# Altium schematic sheet STM32_CORE.SchDoc(STM32_CORE)
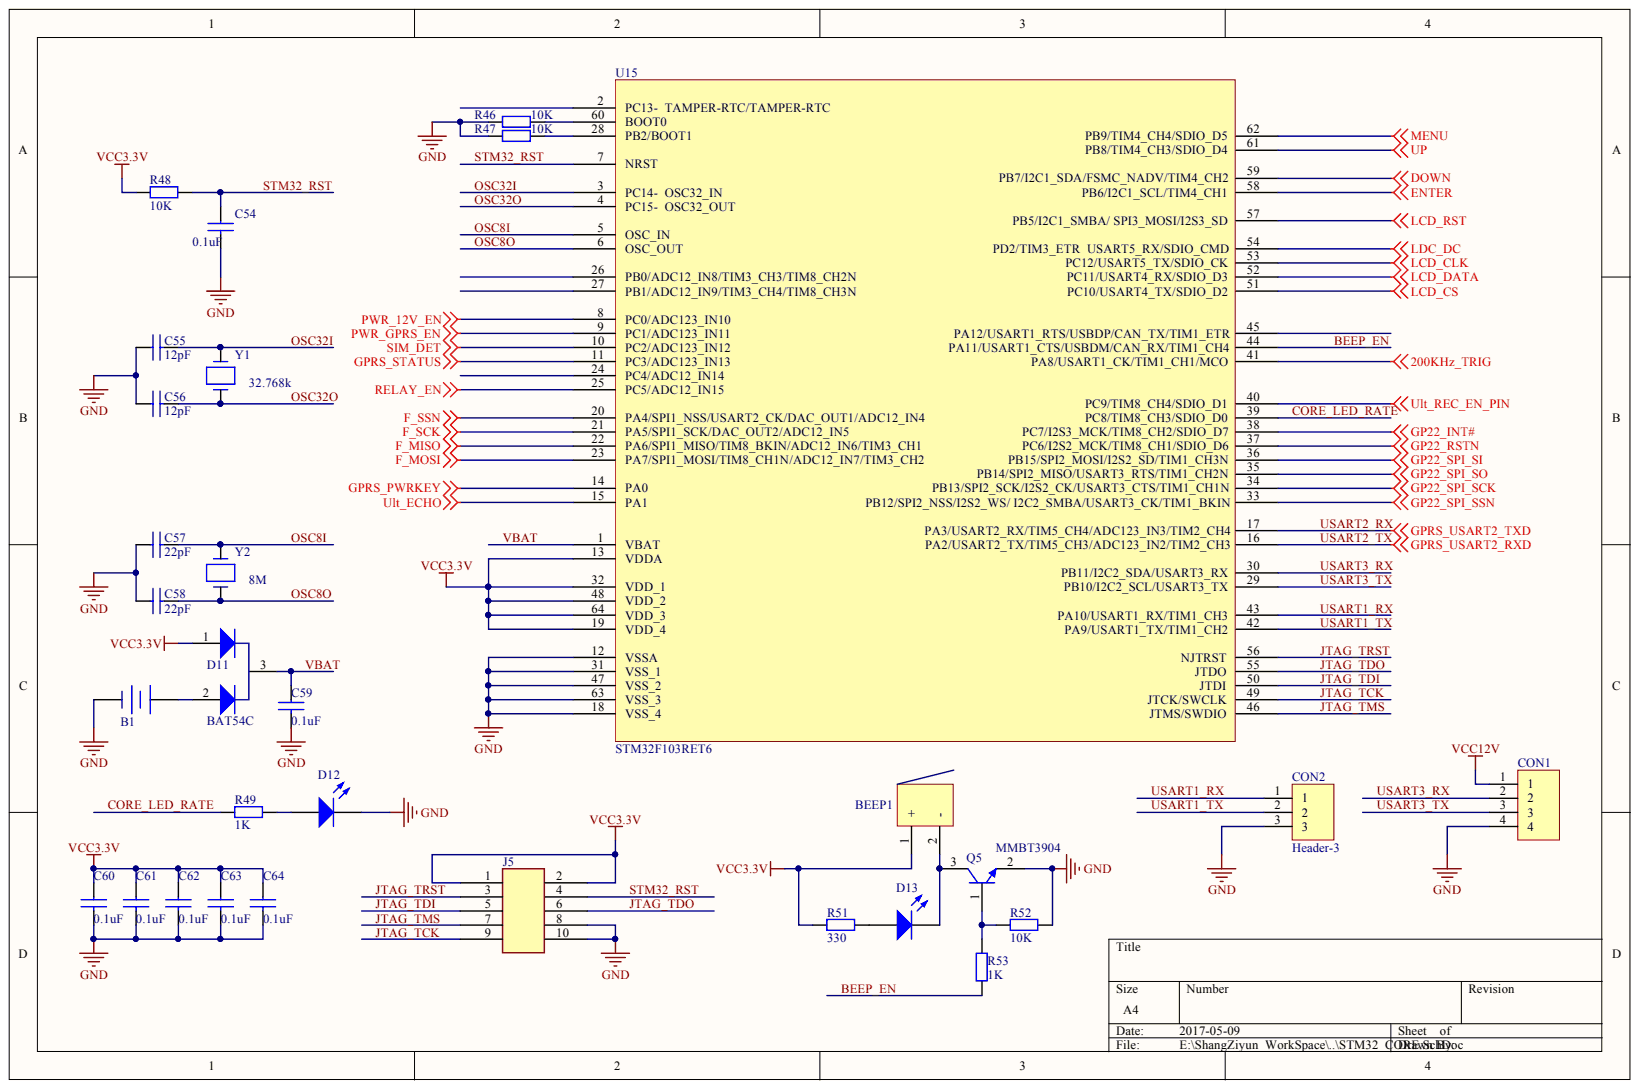
[diagram p0: STM32_CORE.SchDoc]

## Parts
B1  3VCR1202纽扣电池座  [CR1220]  (value not drawn)
BEEP1  Beep  [beep]  (value not drawn)
C54  value 0.1uF  [0603C]  (hidden comment: CAP NP)
C55  value 12pF  [0603C]  (hidden comment: CAP NP)
C56  value 12pF  [0603C]  (hidden comment: CAP NP)
C57  value 22pF  [0603C]  (hidden comment: CAP NP)
C58  value 22pF  [0603C]  (hidden comment: CAP NP)
C59  value 0.1uF  [0603C]  (hidden comment: CAP NP)
C60  value 0.1uF  [0603C]  (hidden comment: CAP NP)
C61  value 0.1uF  [0603C]  (hidden comment: CAP NP)
C62  value 0.1uF  [0603C]  (hidden comment: CAP NP)
C63  value 0.1uF  [0603C]  (hidden comment: CAP NP)
C64  value 0.1uF  [0603C]  (hidden comment: CAP NP)
CON1  CONNECTOR_4PIN  [XH2.54-4]  (value not drawn)
CON2  Header3  value Header-3  [HEADER_3P]
D11  BAT54C  [SOT23]
D12  LED0  [LED_3mm]  (value not drawn)
D13  LED0  [LED_3mm]  (value not drawn)
J5  CON10A  [DC3-10P-2.0]  (value not drawn)
Q5  MMBT3904  [SOT23]
R46  value 10K  [L0603]  (hidden comment: Resistor)
R47  value 10K  [L0603]  (hidden comment: Resistor)
R48  value 10K  [L0603]  (hidden comment: Resistor)
R49  value 1K  [L0603]  (hidden comment: Resistor)
R51  value 330  [L0603]  (hidden comment: Resistor)
R52  value 10K  [L0603]  (hidden comment: Resistor)
R53  value 1K  [L0603]  (hidden comment: Resistor)
U15  STM32F103RET6  [LQFP64]
Y1  value 32.768k  [fc135]  (hidden comment: CRYSTAL_0)
Y2  value 8M  [ABM7]  (hidden comment: CRYSTAL_0)

## Nets
200KHZ_TRIG: U15.41(PA8/USART1_CK/TIM1_CH1/MCO)
DOWN: U15.59(PB7/I2C1_SDA/FSMC_NADV/TIM4_CH2)
ENTER: U15.58(PB6/I2C1_SCL/TIM4_CH1)
F_MISO: U15.22(PA6/SPI1_MISO/TIM8_BKIN/ADC12_IN6/TIM3_CH1)
F_MOSI: U15.23(PA7/SPI1_MOSI/TIM8_CH1N/ADC12_IN7/TIM3_CH2)
F_SCK: U15.21(PA5/SPI1_SCK/DAC_OUT2/ADC12_IN5)
F_SSN: U15.20(PA4/SPI1_NSS/USART2_CK/DAC_OUT1/ADC12_IN4)
GND: B1.2 C54.2 C55.1 C56.1 C57.1 C58.1 C59.2 C60.2 C61.2 C62.2 C63.2 C64.2 CON1.4 CON2.3 D12.2 J5.8 J5.10 Q5.2 R46.1 R47.1 R52.2 U15.12(VSSA) U15.18(VSS_4) U15.31(VSS_1) U15.47(VSS_2) U15.63(VSS_3)
GP22_INT#: U15.38(PC7/I2S3_MCK/TIM8_CH2/SDIO_D7)
GP22_RSTN: U15.37(PC6/I2S2_MCK/TIM8_CH1/SDIO_D6)
GP22_SPI_SCK: U15.34(PB13/SPI2_SCK/I2S2_CK/USART3_CTS/TIM1_CH1N)
GP22_SPI_SI: U15.36(PB15/SPI2_MOSI/I2S2_SD/TIM1_CH3N)
GP22_SPI_SO: U15.35(PB14/SPI2_MISO/USART3_RTS/TIM1_CH2N)
GP22_SPI_SSN: U15.33(PB12/SPI2_NSS/I2S2_WS/_I2C2_SMBA/USART3_CK/TIM1_BKIN)
GPRS_PWRKEY: U15.14(PA0)
GPRS_STATUS: U15.11(PC3/ADC123_IN13)
LCD_CLK: U15.53(PC12/USART5_TX/SDIO_CK)
LCD_CS: U15.51(PC10/USART4_TX/SDIO_D2)
LCD_DATA: U15.52(PC11/USART4_RX/SDIO_D3)
LCD_RST: U15.57(PB5/I2C1_SMBA/_SPI3_MOSI/I2S3_SD)
LDC_DC: U15.54(USART5_RX/SDIO_CMD)
MENU: U15.62(PB9/TIM4_CH4/SDIO_D5)
PWR_12V_EN: U15.8(PC0/ADC123_IN10)
PWR_GPRS_EN: U15.9(PC1/ADC123_IN11)
RELAY_EN: U15.25(PC5/ADC12_IN15)
SIM_DET: U15.10(PC2/ADC123_IN12)
ULT_ECHO: U15.15(PA1)
ULT_REC_EN_PIN: U15.40(PC9/TIM8_CH4/SDIO_D1)
UP: U15.61(PB8/TIM4_CH3/SDIO_D4)
VCC3.3V: BEEP1.1 C60.1 C61.1 C62.1 C63.1 C64.1 D11.1 J5.1 J5.2 R48.1 R51.2 U15.13(VDDA) U15.19(VDD_4) U15.32(VDD_1) U15.48(VDD_2) U15.64(VDD_3)
VCC12V: CON1.1
BEEP_EN: R53.1 U15.44(PA11/USART1_CTS/USBDM/CAN_RX/TIM1_CH4)
CORE_LED_RATE: R49.2 U15.39(PC8/TIM8_CH3/SDIO_D0)
JTAG_TCK: J5.9 U15.49(JTCK/SWCLK)
JTAG_TDI: J5.5 U15.50(JTDI)
JTAG_TDO: J5.6 U15.55(JTDO)
JTAG_TMS: J5.7 U15.46(JTMS/SWDIO)
JTAG_TRST: J5.3 U15.56(NJTRST)
OSC8I: C57.2 U15.5(OSC_IN) Y2.1
OSC8O: C58.2 U15.6(OSC_OUT) Y2.2
OSC32I: C55.2 U15.3(PC14-) Y1.1
OSC32O: C56.2 U15.4(PC15-) Y1.2
STM32_RST: C54.1 J5.4 R48.2 U15.7(NRST)
USART1_RX: CON2.1 U15.43(PA10/USART1_RX/TIM1_CH3)
USART1_TX: CON2.2 U15.42(PA9/USART1_TX/TIM1_CH2)
USART2_RX: U15.17(PA3/USART2_RX/TIM5_CH4/ADC123_IN3/TIM2_CH4)
USART2_TX: U15.16(PA2/USART2_TX/TIM5_CH3/ADC123_IN2/TIM2_CH3)
USART3_RX: CON1.2 U15.30(PB11/I2C2_SDA/USART3_RX)
USART3_TX: CON1.3 U15.29(PB10/I2C2_SCL/USART3_TX)
VBAT: C59.1 D11.3 U15.1(VBAT)
NetB1_1: B1.1 D11.2
NetBEEP1_2: BEEP1.2 D13.2 Q5.3
NetD12_1: D12.1 R49.1
NetD13_1: D13.1 R51.1
NetQ5_1: Q5.1 R52.1 R53.2
NetR46_2: R46.2 U15.60(BOOT0)
NetR47_2: R47.2 U15.28(PB2/BOOT1)

Not connected: U15 2(PC13-) 24(PC4/ADC12_IN14) 26(PB0/ADC12_IN8/TIM3_CH3/TIM8_CH2N) 27(PB1/ADC12_IN9/TIM3_CH4/TIM8_CH3N) 45(PA12/USART1_RTS/USBDP/CAN_TX/TIM1_ETR)

## Library data of the parts
B1 = Battery: Component Kind: Standard; Value: 3VCR1202纽扣电池座; Library Name: zhileng.SchLib
BEEP1 = Beep: Value: 3V有源蜂鸣器; Component Kind: Standard; Library Name: ZL0611.SCHLIB
CON1 = CONNECTOR_4PIN: Value: XH2.54-4P; Component Kind: Standard; Library Name: MyLib.SCHLIB
D12 D13 = LED0805: Typical INFRARED GaAs LED; Value: LED_3mm_RED; Component Kind: Standard; LatestRevisionDate: 08-Jul-2005; LatestRevisionNote: Mech Layer 1 removed.; PackageDescription: LED; 2 Leads; PackageReference: LED-0; Published: 8-Jun-2000; Library Name: 简配版生物罐.SCHLIB; Publisher: Altium Limited
J5 = CON10A: Value: 2.0mm,10P,JTAG座; Component Kind: Standard; Library Name: MAINBOARD_Library.SchLib
Q5 = NPN: Value: 2N3904; Component Kind: Standard; Library Name: LNG.SCHLIB
R46-R49 R51-R53 = Res: Component Kind: Standard; Library Name: Lib1.SCHLIB
U15 = STM32F103RBT6: Component Kind: Standard; Value: STM32F103RET6; Library Name: Bio.SchLib
C54-C64 = CAP NP: Component Kind: Standard; Library Name: MAINBOARD_Library.SchLib
CON2 = Header3: Component Kind: Standard; Library Name: zhileng.SCHLIB
D11 = BAT54C: Component Kind: Standard; Value: BAT54C; Library Name: 简配版生物罐.SCHLIB
Y1 Y2 = CRYSTAL_0: Component Kind: Standard; Library Name: MAINBOARD_Library.SchLib
